# Altium schematic sheet Sheet1.SchDoc
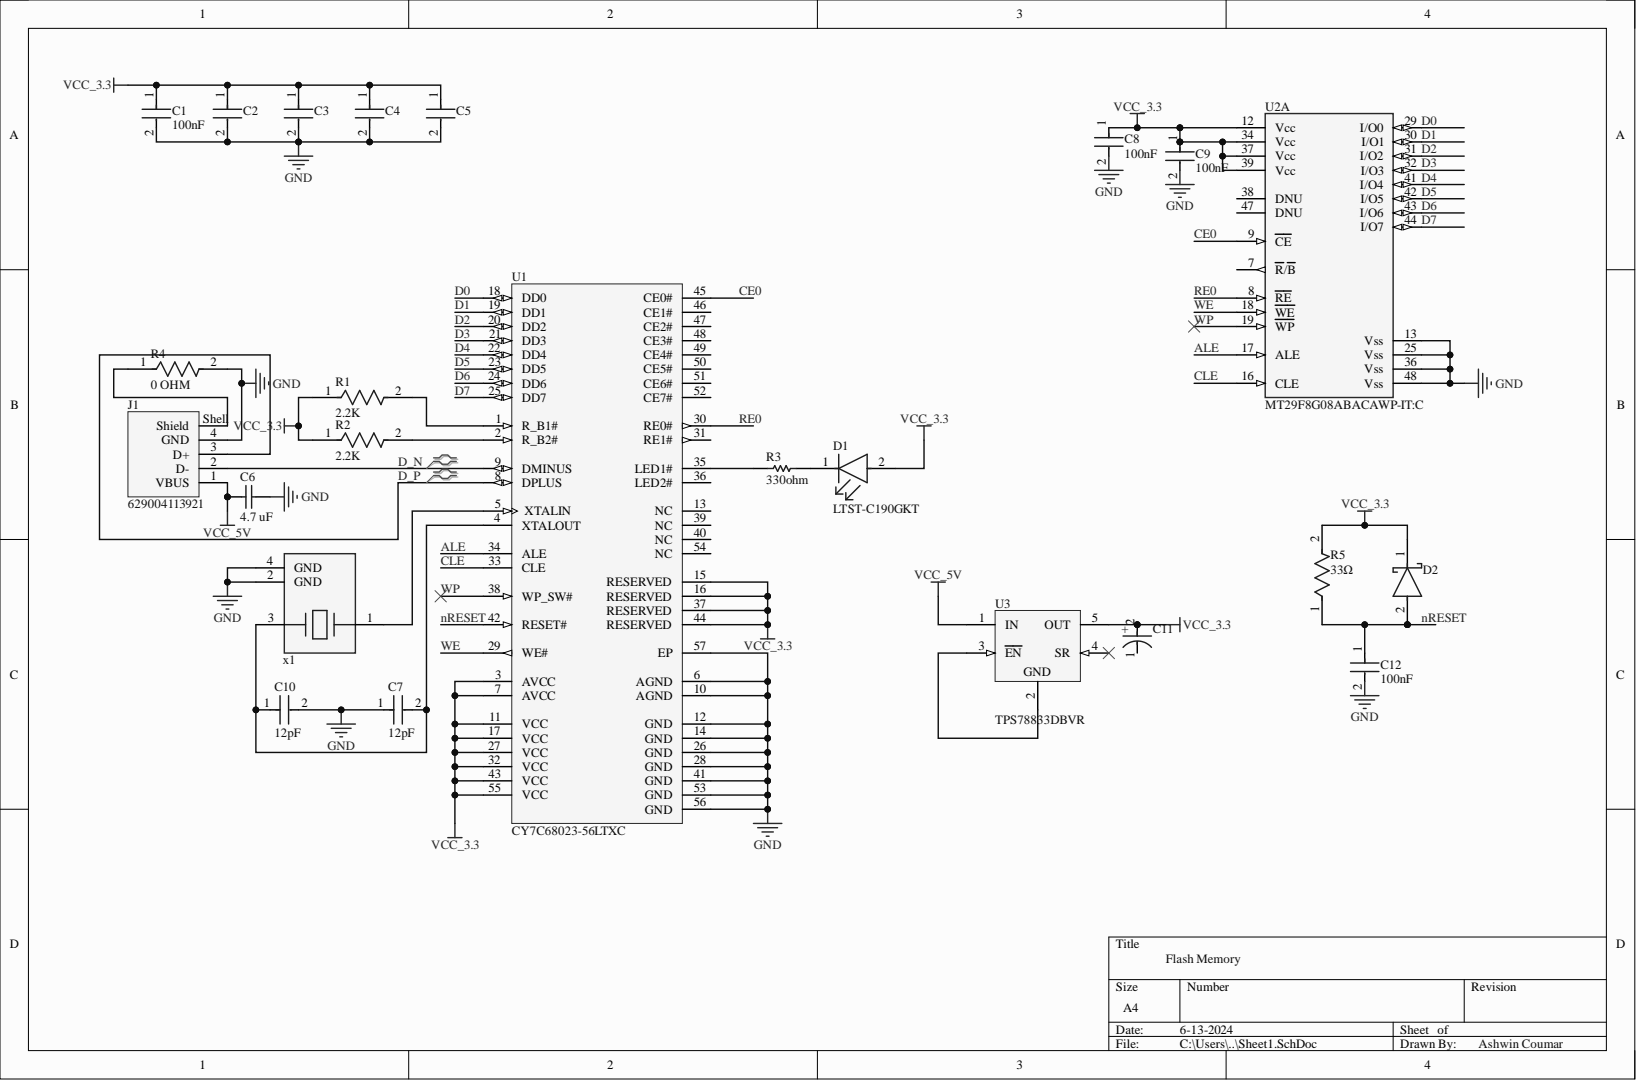
[diagram p0: Sheet1.SchDoc]

## Parts
C1  100nF  [FP-C1005-050-0_05-IPC_C]
C2  C1005X7R1H104K050BB  [FP-C1005-050-0_05-IPC_C]  (value not drawn)
C3  C1005X7R1H104K050BB  [FP-C1005-050-0_05-IPC_C]  (value not drawn)
C4  C1005X7R1H104K050BB  [FP-C1005-050-0_05-IPC_C]  (value not drawn)
C5  C1005X7R1H104K050BB  [FP-C1005-050-0_05-IPC_C]  (value not drawn)
C6  4.7 uF  [CAPC2013X135X45LL10T25]
C7  12pF  [FP-0402-L_1_0_1-W_0_5_0_1-IPC_B]
C8  100nF  [FP-C1005-050-0_05-IPC_C]
C9  100nF  [FP-C1005-050-0_05-IPC_C]
C10  12pF  [FP-0402-L_1_0_1-W_0_5_0_1-IPC_B]
C11  (no value)  [FP-TAJA-MFG]
C12  100nF  [FP-C1005-050-0_05-IPC_C]
D1  LTST-C190GKT  [FP-LTST-C190GKT-MFG]
D2  1N5819HW-7-F  [FP-SOD123-IPC_A]  (value not drawn)
J1  629004113921  [629004113921]
R1  2.2K  [FP-RT0402-MFG]
R2  2.2K  [FP-RT0402-MFG]
R3  330ohm  [RESC1005X40X25NL05T05]
R4  0 OHM  [FP-ERJ-3GEY0R00V-MFG]
R5  33Ω  [FP-RC0402-IPC_C]
U1  CY7C68023-56LTXC  [CYP-LTX56-5200X4500TP_M]
U2  MT29F8G08ABACAWP-IT:C  [FP-WP-IPC_C]  (part A)
U3  TPS78833DBVR  [DBV0005A_M]
x1  ABM3B-24.000MHZ-B2-T  [FP-ABM3B-MFG]  (value not drawn)

## Nets
GND: C1.2 C2.2 C3.2 C4.2 C5.2 C6.1 C7.1 C8.2 C9.2 C10.2 C12.2 J1.4(GND) R4.2 U1.6(AGND) U1.10(AGND) U1.12(GND) U1.14(GND) U1.26(GND) U1.28(GND) U1.41(GND) U1.53(GND) U1.56(GND) U1.57(EP) U2.13(Vss) U2.25(Vss) U2.36(Vss) U2.48(Vss) x1.2(GND) x1.4(GND)
VCC_3.3: C1.1 C2.1 C3.1 C4.1 C5.1 C8.1 C9.1 C11.2 D1.2 D2.1 R1.1 R2.1 R5.2 U1.3(AVCC) U1.7(AVCC) U1.11(VCC) U1.15(RESERVED) U1.16(RESERVED) U1.17(VCC) U1.27(VCC) U1.32(VCC) U1.37(RESERVED) U1.43(VCC) U1.44(RESERVED) U1.55(VCC) U2.12(Vcc) U2.34(Vcc) U2.37(Vcc) U2.39(Vcc) U3.5(OUT)
VCC_5V: C6.2 J1.1(VBUS) U3.1(IN)
ALE: U1.34(ALE) U2.17(ALE)
CE0: U1.45(CE0#) U2.9(CE)
CLE: U1.33(CLE) U2.16(CLE)
D0: U1.18(DD0) U2.29(I/O0)
D1: U1.19(DD1) U2.30(I/O1)
D2: U1.20(DD2) U2.31(I/O2)
D3: U1.21(DD3) U2.32(I/O3)
D4: U1.22(DD4) U2.41(I/O4)
D5: U1.23(DD5) U2.42(I/O5)
D6: U1.24(DD6) U2.43(I/O6)
D7: U1.25(DD7) U2.44(I/O7)
D_N: J1.2(D-) U1.9(DMINUS)
D_P: J1.3(D+) U1.8(DPLUS)
nRESET: C12.1 D2.2 R5.1 U1.42(RESET#)
RE0: U1.30(RE0#) U2.8(RE)
WE: U1.29(WE#) U2.18(WE)
WP: U1.38(WP_SW#) U2.19(WP)
NetC7_2: C7.2 C10.1 U1.4(XTALOUT) x1.3
NetD1_1: D1.1 R3.2
NetJ1_Shell: J1.Shell(Shield) R4.1
NetR1_2: R1.2 U1.1(R_B1#)
NetR2_2: R2.2 U1.2(R_B2#)
NetR3_1: R3.1 U1.35(LED1#)
NetU1_5: U1.5(XTALIN) x1.1
NetU3_2: U3.2 U3.3(EN)

Not connected: C11 1; U1 13(NC) 31(RE1#) 36(LED2#) 39(NC) 40(NC) 46(CE1#) 47(CE2#) 48(CE3#) 49(CE4#) 50(CE5#) 51(CE6#) 52(CE7#) 54(NC); U2 7(R/B) 38(DNU) 47(DNU); U3 4(SR)

## Library data of the parts
C1-C5 C8 C9 C12 = CMP-2000-05393-2: Multilayer Ceramic Capacitors 100nF ±10% 50V X7R SMD 0402; Capacitance: 100nF; Case Code (Imperial): 0402; Case Code (Metric): 1005; Case/Package: 0402; Component Kind: Standard; Construction: Flat; Depth: 0.5mm; Dielectric: X7R; Dielectric Material: Ceramic; Height: 0.5mm; Lead Free: Lead Free; Length: 1mm; Library Name: Altium Content Vault; Max Operating Temperature: 125°C; Min Operating Temperature: -55°C; Mount: Surface Mount; Package Quantity: 1; Packaging: Tape and Reel; Radiation Hardening: No; REACH SVHC: No SVHC; Resistor Type: Ceramic; RoHS Compliant: Yes; Series: C; Termination: SMD/SMT; Thickness: 0.022inch; Tolerance: 10%; Voltage: 50V; Voltage Rating: 50V; Voltage Rating (DC): 50V; Weight: 2.3E-05oz; Width: 0.02inch
C6 = CMP-1662-00015-2: Chip Capacitor, 4.7 uF, +/- 10%, 16 V, -55 to 85 degC, 0805 (2012 Metric), RoHS, Tape and Reel; PartNumber: GRM21BR61C475KA88L; Case\/Package: 0805; Type (Capacitors): X5R; Voltage Rating: 16 V; Capacitance: 4.7 uF; Case Code (Imperial): 0805; Case Code (Metric): 2012; Component Kind: Standard; ComponentLink1Description: Manufacturer URL; ComponentLink1URL: http://www.murata.com/; ComponentLink2Description: Datasheet; ComponentLink2URL: http://search.murata.co.jp/Ceramy/image/img/A01X/G101/ENG/GRM21BR61C475KA88-01.pdf; DatasheetVersion: 03/2016; Height: 1.25 mm; Length: 2 mm; Library Name: Altium Content Vault; Max Operating Temperature: 85 degC; Min Operating Temperature: -55 degC; Mount: Surface Mount; Mounting Technology: Surface Mount; Package Quantity: 3000; PackageDescription: 2-Pin Surface Mount Device, Body 2 x 1.25 mm; PackageReference: 0805; Packaging: Tape and Reel; Pins: 2; Radiation Hardening: No; REACH SVHC: No SVHC; RoHSCompliant: true; Tolerance: 10%; Voltage Rating (DC): 16 V; Width: 1.25 mm
C7 C10 = CMP-2008-02574-2: General Purpose Ceramic Capacitor, 0402, 12pF, 5%, C0G, 30ppm/°C, 50V; Insulation Resistance: 100GR; Capacitance: 12pF; Case Code (Imperial): 0402; Case Code (Metric): 1005; Case/Package: 0402; Component Kind: Standard; Construction: Flat; Depth: 0.5mm; Dielectric: C0G; Height: 0.5mm; Lead Free: Lead Free; Length: 1mm; Library Name: Altium Content Vault; Max Operating Temperature: 125°C; Min Operating Temperature: -55°C; Mount: Surface Mount; Number of Pins: 2; Package Quantity: 1; Packaging: Tape and Reel; Radiation Hardening: No; REACH SVHC: No SVHC; RoHS Compliant: Yes; Series: -; Temperature Coefficient: 30ppm/°C; Termination: SMD/SMT; Thickness: 0.022inch; Tolerance: 5%; Voltage: 50V; Voltage Rating: 50V; Voltage Rating (DC): 50V; Width: 0.02inch
C11 = CMP-1661-00004-2: 10uF 16V  ±10% 3Ω 1206  SMD Tantalum Capacitor; Dissipation Factor: 8%; ESR (Equivalent Series Resistance): 3R; Leakage Current: 1.6uA; Polarity: Polar; Capacitance: 10uF; Case Code (Imperial): 1206; Case Code (Metric): 3216; Case/Package: 1206; Component Kind: Standard; Depth: 1.6mm; Dielectric Material: Tantalum; Features: General Purpose; Height: 1.6mm; Height - Seated (Max): 0.071inch; Lead Free: Lead Free; Lead Pitch: 1.1mm; Length: 3.2mm; Library Name: Altium Content Vault; Max Operating Temperature: 125°C; Min Operating Temperature: -55°C; Mount: Surface Mount; Number of Pins: 2; Package Quantity: 1; Packaging: Tape and Reel; Radiation Hardening: No; REACH SVHC: No SVHC; Termination: SMD/SMT; Tolerance: 10%; Voltage: 16V; Voltage Rating: 16V; Voltage Rating (DC): 16V; Width: 1.6mm
D1 = CMP-22017-000005-2: LED GREEN CLEAR CHIP SMD; Dominant Wavelength: 569nm; Forward Current: 30mA; Forward Voltage: 2.1V; Lens Color: Colorless; Luminous Intensity: 6mcd; Number of Elements: 1; Power Dissipation: 100mW; Reverse Voltage: 5V; Test Current: 10mA; Viewing Angle: 130°; Voltage: 2V; Wavelength: 565nm; Case Code (Imperial): 0603; Case Code (Metric): 1608; Case/Package: 0603; Color: Green; Component Kind: Standard; Depth: 0.8mm; Height: 0.8mm; Illumination Color: Green; Lead Free: Lead Free; Length: 1.6mm; Lens Style: Clear; Lens Transparency: Clear; Library Name: Altium Content Vault; Max Operating Temperature: 85°C; Min Operating Temperature: -55°C; Mount: Surface Mount; Number of LEDs: 1; Number of Pins: 2; Package Quantity: 1; Packaging: Tape and Reel; Power Rating: 100mW; Radiation Hardening: No; Width: 0.8mm
D2 = CMP-0303-00003-3: DIODE SCHOTTKY 40V 1A SOD123; Capacitance: 110pF; Element Configuration: Single; Forward Voltage: 450mV; Max Forward Surge Current (Ifsm): 25A; Max Power Dissipation: 450mW; Peak Reverse Current: 50uA; Polarity: Standard; Average Rectified Current: 1A; Case/Package: SOD-123-2; Component Kind: Standard; Contact Plating: Tin; Current Rating: 1A; Forward Current: 1A; Height: 1.25mm; Lead Free: Lead Free; Length: 2.85mm; Library Name: Altium Content Vault; Max Operating Temperature: 125°C; Max Repetitive Reverse Voltage (Vrrm): 40V; Max Reverse Voltage (DC): 40V; Min Operating Temperature: -65°C; Number of Pins: 2; Output Current: 1A; Package Quantity: 3000; Packaging: Tape and Reel; Peak Non-Repetitive Surge Current: 25A; Radiation Hardening: No; REACH SVHC: No SVHC; Termination: SMD/SMT; Voltage Rating (DC): 40V; Weight: 0.000353oz; Width: 1.7mm
J1 = CMP-1502-03242-2: NexarPartId: 19991169; Connector Type: USB; Contact Resistance: 20mΩ; Current Rating: 1.5A; Gender: Male; Insulation Resistance: 1GΩ; Number of Contacts: 4; Operating Supply Voltage: 30V; USB Standard: USB 2.0; Component Kind: Standard; Contact Plating: Gold; Features: Shielded; Lead Free: Lead Free; Length: 18.8mm; Library Name: Altium Content Vault; Max Operating Temperature: 105°C; Min Operating Temperature: -40°C; Mount: Horizontal, Surface Mount; Number of Pins: 4; Orientation: Horizontal; REACH SVHC: Unknown; RoHS: Compliant; Termination: SMD/SMT
R1 R2 = CMP-2002-02636-2: RES SMD 2.2K OHM 0.1% 1/16W 0402; Manufacturer: Yageo; Manufacturer Part Number: RT0402BRD072K2L; Power Rating: 0.063W; Resistance: 2.2KΩ; Temperature Coefficient: 25 ppm/°C; Tolerance: 0.001; Value: 2.2KΩ; Voltage Rating: 50V; Automotive: No; Category: Res; Component Kind: Standard; ComponentLink2Description: Datasheet; ComponentLink2URL: http://www.yageo.com/documents/recent/PYu-RT_1-to-0.01_RoHS_L_9.pdf; Composition: Thin Film; Device Class L1: Passive Components; Device Class L2: Resistors; Device Class L3: Chip SMD Resistors; Digikey Description: RES SMD 2.2K OHM 0.1% 1/16W 0402; Footprint Url: http://www.yageo.com/exep/pages/download/literatures/PYu-R_Mount_10.pdf; Height: 0.35mm; Ipc Land Pattern Name: RESC10050X30; Lead Free: Yes; Library Name: Altium Content Vault; Mouser Description: Thin Film Resistors 1/16W 2.2K ohm .1% 25ppm; Octopart: https://octopart.com/rt0402brd072k2l-yageo-1369928; Package: RT0402; RoHS: TRUE; Series: RT; Temperature: -55°C to +155°C; Temperature Range High: +155°C; Temperature Range Low: -55°C
R3 = CMP-2002-00515-1: Manufacturer: Panasonic; Part Number: ERJ-2GEJ331X; Component Kind: Standard; Library Name: Altium Content Vault
R4 = CMP-2000-00700-2: RES SMD 0 OHM JUMPER 1/10W 0603; Manufacturer: Panasonic; Manufacturer Part Number: ERJ-3GEY0R00V; Automotive Grade: Grade 0; Power Rating: 0.1W; Resistance: 0Ω; Temperature Coefficient: -100/+600ppm/°C; Tolerance: 5%; Value: 0Ω; Voltage Rating: 75V; Automotive: Yes; Category: Res; Component Kind: Standard; ComponentLink2Description: Datasheet; ComponentLink2URL: https://industrial.panasonic.com/cdbs/www-data/pdf/RDA0000/AOA0000C301.pdf; Composition: Thick Film; Device Class L1: Passive Components; Device Class L2: Resistors; Device Class L3: Chip SMD Resistors; Digikey Description: RES SMD 0 OHM JUMPER 1/10W 0603; Footprint Url: https://industrial.panasonic.com/cdbs/www-data/pdf/RDA0000/DMA0000COL17.pdf; Height: 0.55mm; Ipc Land Pattern Name: RESC16080X45; Lead Free: Yes; Library Name: Altium Content Vault; Mouser Description: Resistor, Chip Thick Film, 0 Ohm, 0.1w, Resistor, Chip Thick Film, 0 Ohm, 0.1w, 5%; Octopart: https://octopart.com/erj-3gey0r00v-panasonic-55389238; Package: 0603; RoHS: TRUE; Series: ERJ; Temperature: -55°C to +155°C; Temperature Range High: +155°C; Temperature Range Low: -55°C
R5 = CMP-13261-005364-1: Res Thick Film 01005 33Ω 5% 0.03W ±250ppm/°C Molded SMD T/R; Max Power Dissipation: 30mW; Resistance: 33R; Temperature Coefficient: 300ppm/°C; Voltage Rating (DC): 15V; Case Code (Imperial): 1005; Case Code (Metric): 0402; Component Kind: Standard; Composition: Thick Film; Features: Moisture Resistant; Height: 0.006inch; Lead Free: Lead Free; Length: 0.016inch; Library Name: Altium Content Vault; Max Operating Temperature: 125°C; Min Operating Temperature: -55°C; Number of Terminations: 2; Package Quantity: 1; Packaging: Tape and Reel; Tolerance: 5%; Width: 0.008inch
U1 = CMP-0967-00114-2: EZ-USB NX2LP(TM) USB 2.0 NAND Flash Controller, 8 I/Os, 3 to 3.6 V, 0 to 70 degC, 56-Pin QFN (LTX56), Tray; Manufacturer: Cypress; Max. Operating Voltage (V): 3.6; Min. Operating Voltage (V): 3; No. of I/Os: 8; Code_JEDEC: MO-220; Component Kind: Standard; ComponentLink1Description: Manufacturer URL; ComponentLink1URL: http://www.cypress.com/; ComponentLink2Description: Datasheet; ComponentLink2URL: http://www.cypress.com/?docID=38242; ComponentLink3Description: Package Specification; ComponentLink3URL: http://www.cypress.com/?docID=47572; DatasheetVersion: Rev. *G; Library Name: Altium Content Vault; Max. Operating Temp. (degreesC): 70; Min. Operating Temp. (degreesC): 0; Mounting Technology: Surface Mount; PackageDescription: 56-Pin Sawn QFN, Body 8 x 8 mm, Pitch 0.5 mm; PackageReference: LTX56; PackageVersion: Rev. *D; Packing: Tray; PartNumber: CY7C68023-56LTXC; RoHS: Pb-Free
U2 = CMP-14958-000015-1: IC FLASH 8GBIT PARALLEL 48TSOP I; Address Bus Width: 31b; Density: 8Gb; Max Supply Voltage: 3.6V; Memory Size: 1000000000B; Min Supply Voltage: 2.7V; Nominal Supply Current: 35mA; Operating Supply Voltage: 3.3V; Page Size: 4KB; Sync/Async: Asynchronous; Word Size: 8b; Case/Package: TFSOP; Component Kind: Standard; Contact Plating: Tin; Interface: Parallel; Library Name: Altium Content Vault; Max Operating Temperature: 85°C; Memory Type: FLASH; Min Operating Temperature: -40°C; Mount: Surface Mount; Number of Pins: 48; Radiation Hardening: No; Series: -; Supply Current: 35mA
U3 = CMP-0394-00627-2: Single Output Low Noise LDO, 150 mA, Fixed 3.3 V Output, 2.7 to 10 V Input, with Low IQ, 5-pin SOT-23 (DBV), 0 to 70 degC, Green (RoHS & no Sb/Br); Manufacturer: Texas Instruments; Accuracy(%): 3; Fixed Output Options(V): 3.3; Iout(Max)(A): 0.1; Iq(Typ)(mA): 0.02; SubFamily: Single Channel LDO; Vdo(Typ)(mV): 150; Vin(Max)(V): 10; Vin(Min)(V): 2.7; Code_JEDEC: MO-178; Component Kind: Standard; ComponentLink1Description: Manufacturer URL; ComponentLink1URL: http://www.ti.com/; ComponentLink2Description: Datasheet; ComponentLink2URL: http://www.ti.com/general/docs/lit/getliterature.tsp?genericPartNumber=TPS78833&fileType=pdf; ComponentLink3Description: Package Specification; ComponentLink3URL: http://www.ti.com/litv/pdf/mpds018i; DatasheetVersion: SLVS382A; Eco-Plan: Green (RoHS & no Sb/Br); Library Name: Altium Content Vault; Mounting Technology: Surface Mount; PackageDescription: 5-Pin Plastic Small Outline, Body 1.60 Ãƒâ€” 2.90 mm, Pitch 0.95 mm; PackageReference: DBV0005A; PackageVersion: 4073253-4/K; PartNumber: TPS78833DBVR; Vout Adj(Max)(V): 3.3; Vout Adj(Min)(V): 3.3
x1 = CMP-1688-00008-2: Crystal 24MHz ±20ppm 18pF SMD-4 5mm x 3.2mm; ESR (Equivalent Series Resistance): 50R; Frequency Stability: 50ppm; Case/Package: CSMD; Component Kind: Standard; Depth: 3.2mm; Frequency: 24MHz; Frequency Tolerance: 20ppm; Height: 0.043inch; Lead Free: Lead Free; Length: 5mm; Library Name: Altium Content Vault; Load Capacitance: 18pF; Max Operating Temperature: 70°C; Min Operating Temperature: -20°C; Mount: Surface Mount; Number of Pins: 4; Operating Frequency: 24 MHz; Package Quantity: 1000; Packaging: Tape and Reel; REACH SVHC: No SVHC; Resistor Type: Ceramic; Series: ABM3B; Series Resistance: 50R; Termination: SMD/SMT; Tolerance: 20ppm; Width: 0.126inch
